# Altium schematic sheet P3T1755.SchDoc(P3T1755)
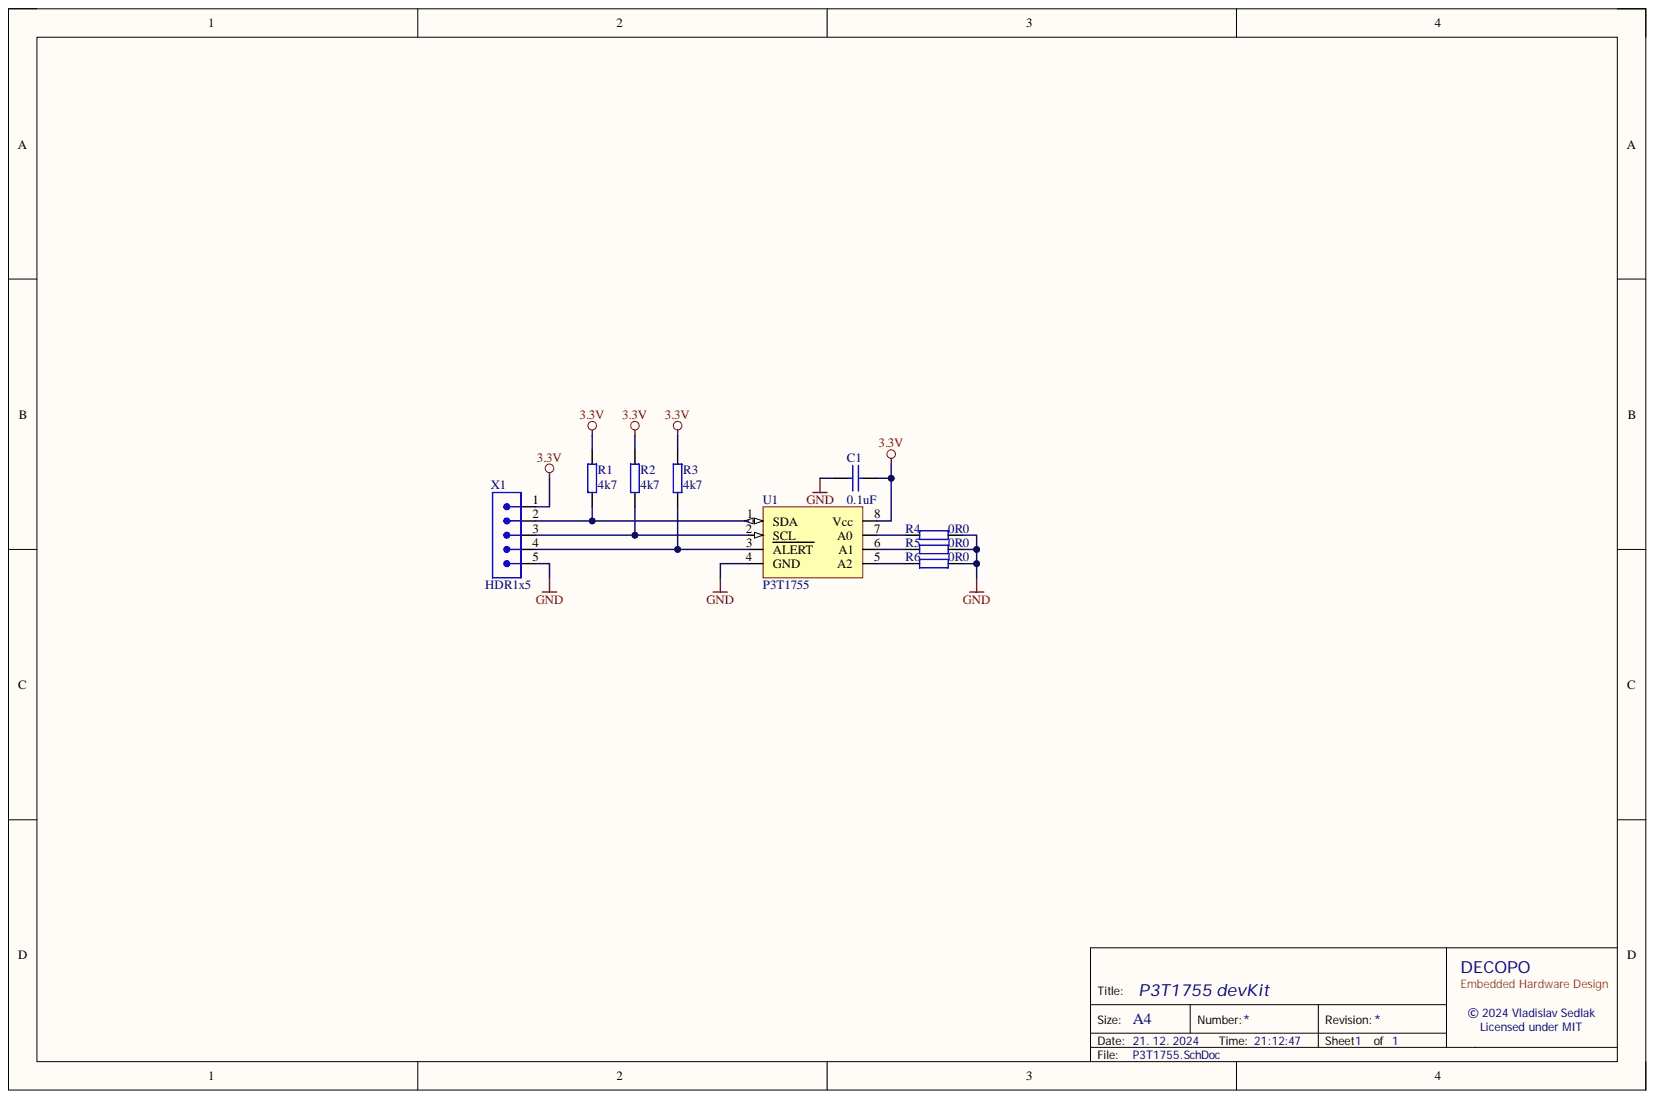
[diagram p0: P3T1755.SchDoc]

## Parts
C1  0.1uF  [FP-C1608-080-0_1-IPC_B]
R1  4k7  [FP-CRCW0603-e3-IPC_B]
R2  4k7  [FP-CRCW0603-e3-IPC_B]
R3  4k7  [FP-CRCW0603-e3-IPC_B]
R4  0R0  [FP-CRCW0603-e3-IPC_B]
R5  0R0  [FP-CRCW0603-e3-IPC_B]
R6  0R0  [FP-CRCW0603-e3-IPC_B]
U1  P3T1755  [TSOP65P490X110-8N]
X1  HDR1x5  [pin hdr 2.54 1x5]

## Nets
3.3V: C1.2 R1.2 R2.2 R3.2 U1.8(Vcc) X1.1
GND: C1.1 R4.2 R5.2 R6.2 U1.4(GND) X1.5
NetR1_1: R1.1 U1.1(SDA) X1.2
NetR2_1: R2.1 U1.2(SCL) X1.3
NetR3_1: R3.1 U1.3(ALERT) X1.4
NetR4_1: R4.1 U1.7(A0)
NetR5_1: R5.1 U1.6(A1)
NetR6_1: R6.1 U1.5(A2)

## Library data of the parts
C1 = 149: Capacitor 0.1uF 0603 50V X7R 10%; Database Table Name: Capacitors$; Component Kind: Standard; Dielectric: X7R; Max Operating Temperature: 125°C; Min Operating Temperature: -55°C; Package: 0603; PartType: Capacitor; Tolerance: 10%; Unique: 149; Value: 0.1uF; Voltage Rating: 50V; Library Name: pasive.DbLib
R1-R3 = 136: Resistor 4k7 0603 1% 100mW; Database Table Name: Resistors$; Temperature Coefficient: 100ppm/°C; Voltage Rating: 75V; Component Kind: Standard; Max Operating Temperature: 155°C; Max Power Dissipation: 100mW; Min Operating Temperature: -55°C; Package: 0603; PartType: Resistor; Tolerance: 1%; Unique: 136; Value: 4k7; Library Name: pasive.DbLib
R4-R6 = 91: Resistor 0R0 0603 1% 100mW; Database Table Name: Resistors$; Temperature Coefficient: 100ppm/°C; Voltage Rating: 75V; Component Kind: Standard; Max Operating Temperature: 155°C; Max Power Dissipation: 100mW; Min Operating Temperature: -55°C; Package: 0603; PartType: Resistor; Tolerance: 1%; Unique: 91; Value: 0R0; Library Name: pasive.DbLib
U1 = P3T1755: I3C digital temperature sensor; Component Kind: Standard; Library Name: ic.SchLib
X1 = 5: Header Male 1x5 2.54mm Gold THT; Database Table Name: Pinhead$; MountingAngle: Straight; Rows: 1; Component Kind: Standard; ContactPlating: Gold; Gender: Male; Library Name: connector.DbLib; Max Operating Temperature: 125°C; Min Operating Temperature: -55°C; MountingStyle: THT; PartType: Header; Pins: 5; Pitch: 2.54mm; Unique: 5; Value: HDR1x5
